# Altium schematic sheet DSP.SchDoc
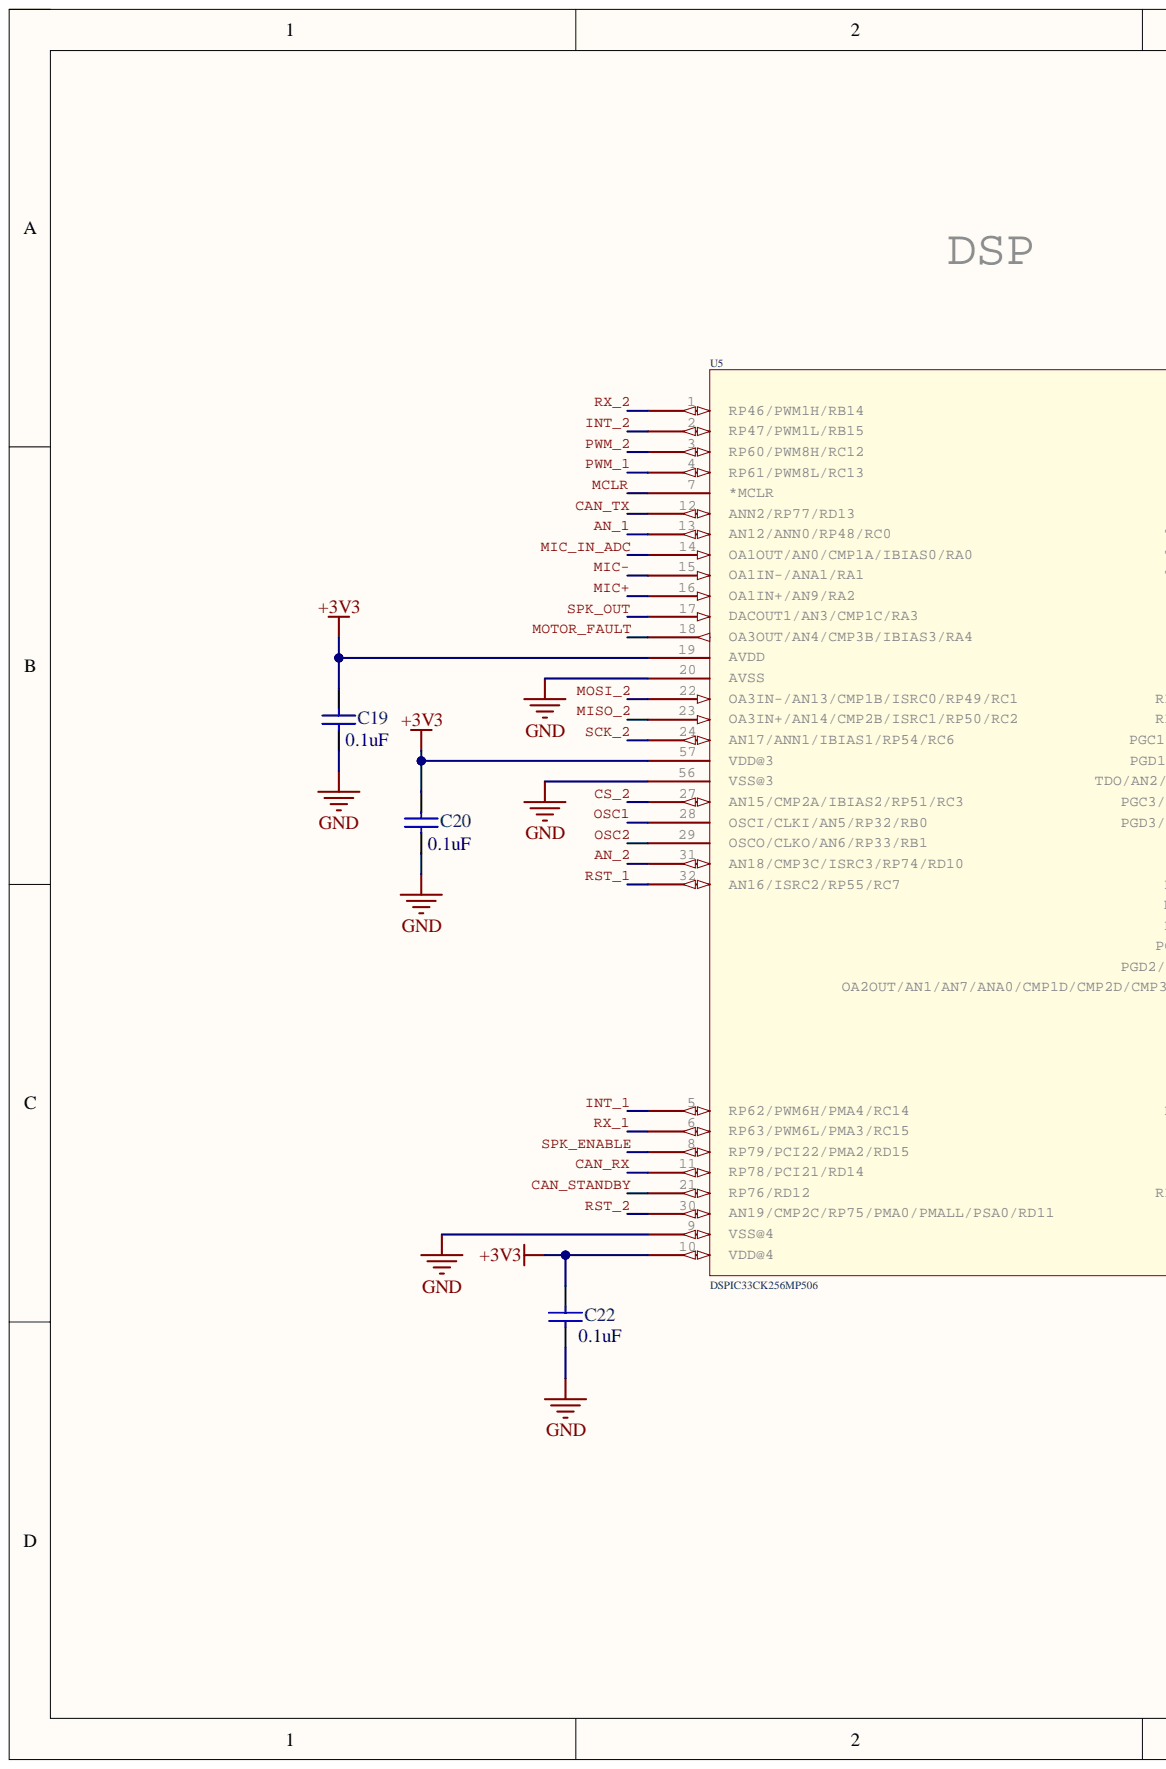
[diagram p0: DSP.SchDoc, left]
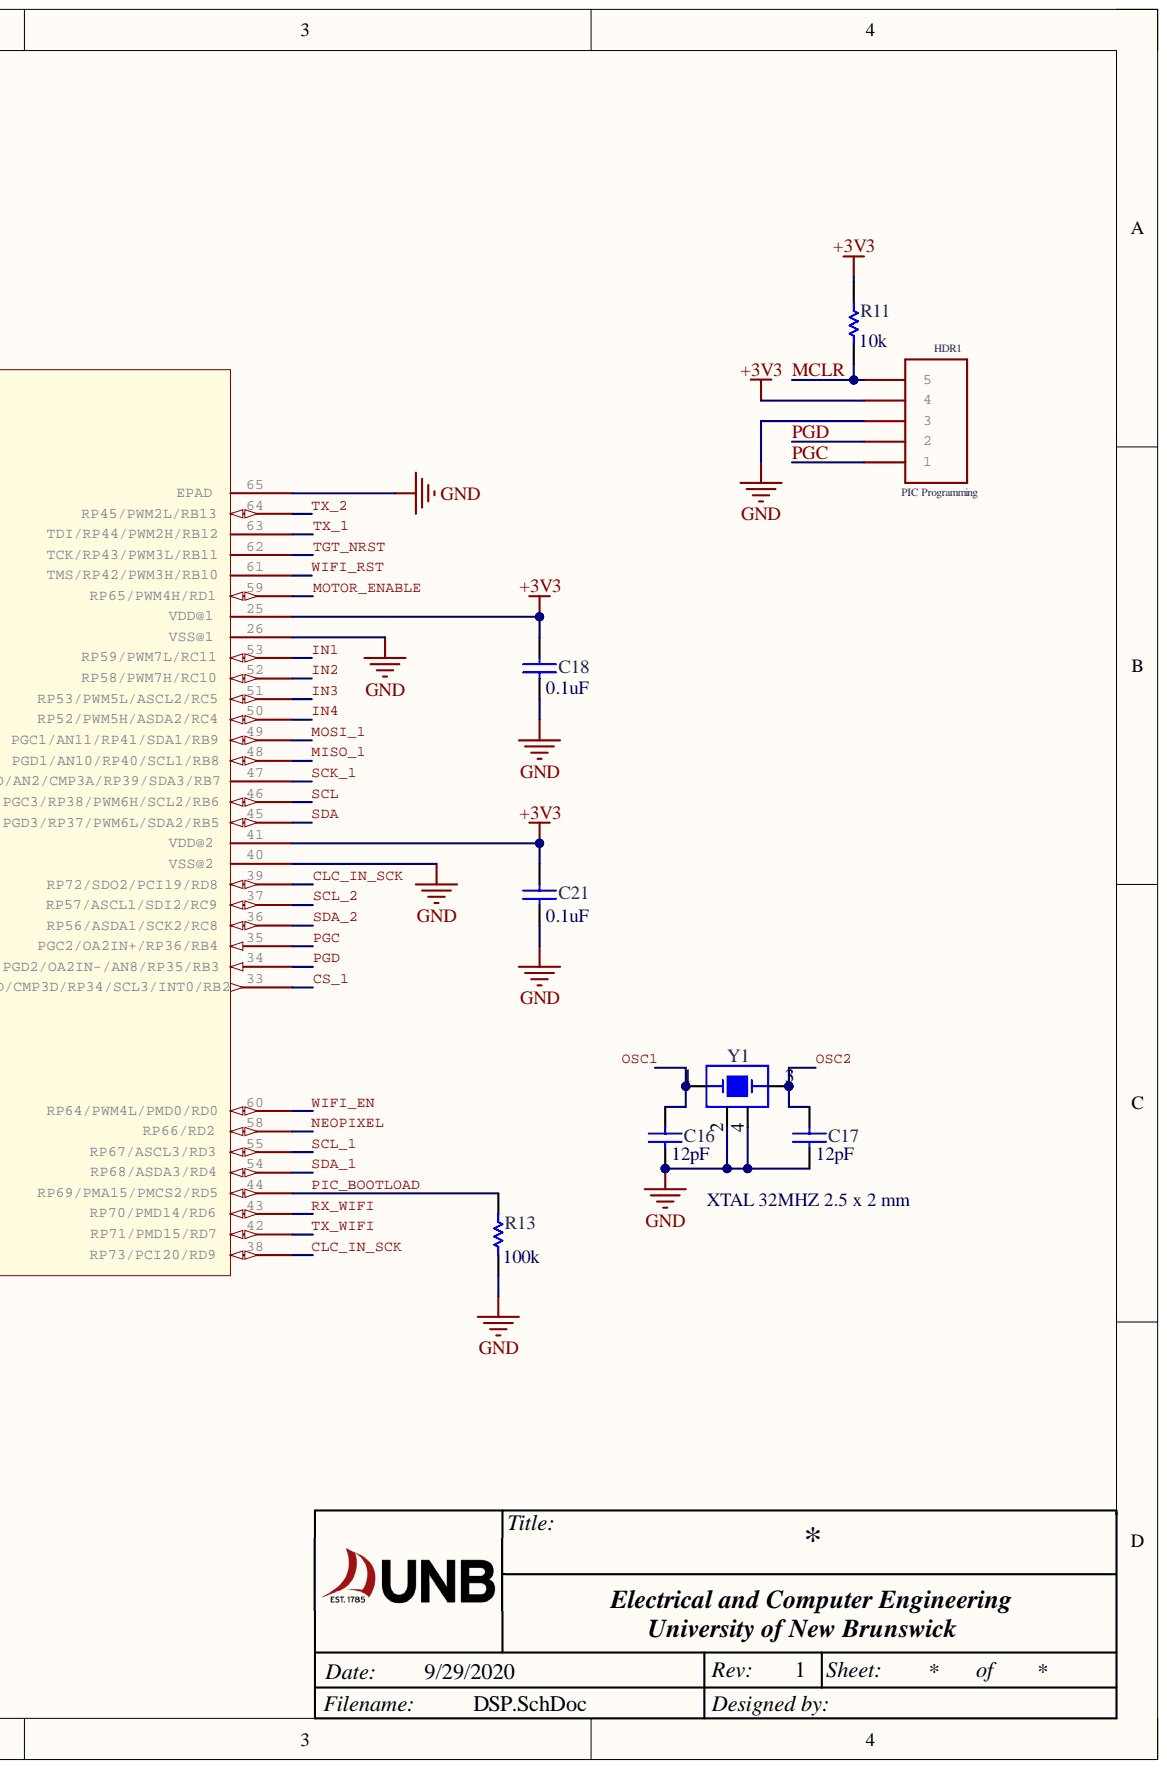
[diagram p1: DSP.SchDoc, right]

## Parts
C16  12pF  [0603 Cap]
C17  12pF  [0603 Cap]
C18  0.1uF  [0603 Cap]
C19  0.1uF  [0603 Cap]
C20  0.1uF  [0603 Cap]
C21  0.1uF  [0603 Cap]
C22  0.1uF  [0603 Cap]
HDR1  PIC Programming  [HDR1X5]  (value not drawn)
R11  10k  [0603 Resistor]
R13  100k  [0603 Resistor]
U5  DSPIC33CK256MP506  [QFN50P900X900X100-65N]
Y1  XTAL 32MHZ 2.5 x 2 mm  [BT-XTAL_2520]

## Nets
+3V3: C18.2 C19.2 C20.2 C21.2 C22.2 HDR1.4 R11.2 U5.10(VDD@4) U5.19(AVDD) U5.25(VDD@1) U5.41(VDD@2) U5.57(VDD@3)
GND: C16.1 C17.1 C18.1 C19.1 C20.1 C21.1 C22.1 HDR1.3 R13.1 U5.9(VSS@4) U5.20(AVSS) U5.26(VSS@1) U5.40(VSS@2) U5.56(VSS@3) U5.65(EPAD) Y1.2 Y1.4
AN_1: U5.13(AN12/ANN0/RP48/RC0)
AN_2: U5.31(AN18/CMP3C/ISRC3/RP74/RD10)
CAN_RX: U5.11(RP78/PCI21/RD14)
CAN_STANDBY: U5.21(RP76/RD12)
CAN_TX: U5.12(ANN2/RP77/RD13)
CLC_IN_SCK: U5.38(RP73/PCI20/RD9) U5.39(RP72/SDO2/PCI19/RD8)
CS_1: U5.33(OA2OUT/AN1/AN7/ANA0/CMP1D/CMP2D/CMP3D/RP34/SCL3/INT0/RB2)
CS_2: U5.27(AN15/CMP2A/IBIAS2/RP51/RC3)
IN1: U5.53(RP59/PWM7L/RC11)
IN2: U5.52(RP58/PWM7H/RC10)
IN3: U5.51(RP53/PWM5L/ASCL2/RC5)
IN4: U5.50(RP52/PWM5H/ASDA2/RC4)
INT_1: U5.5(RP62/PWM6H/PMA4/RC14)
INT_2: U5.2(RP47/PWM1L/RB15)
MCLR: HDR1.5 R11.1 U5.7(*MCLR)
MIC+: U5.16(OA1IN+/AN9/RA2)
MIC-: U5.15(OA1IN-/ANA1/RA1)
MIC_IN_ADC: U5.14(OA1OUT/AN0/CMP1A/IBIAS0/RA0)
MISO_1: U5.48(PGD1/AN10/RP40/SCL1/RB8)
MISO_2: U5.23(OA3IN+/AN14/CMP2B/ISRC1/RP50/RC2)
MOSI_1: U5.49(PGC1/AN11/RP41/SDA1/RB9)
MOSI_2: U5.22(OA3IN-/AN13/CMP1B/ISRC0/RP49/RC1)
MOTOR_ENABLE: U5.59(RP65/PWM4H/RD1)
MOTOR_FAULT: U5.18(OA3OUT/AN4/CMP3B/IBIAS3/RA4)
NEOPIXEL: U5.58(RP66/RD2)
OSC1: C16.2 U5.28(OSCI/CLKI/AN5/RP32/RB0) Y1.1
OSC2: C17.2 U5.29(OSCO/CLKO/AN6/RP33/RB1) Y1.3
PGC: HDR1.1 U5.35(PGC2/OA2IN+/RP36/RB4)
PGD: HDR1.2 U5.34(PGD2/OA2IN-/AN8/RP35/RB3)
PIC_BOOTLOAD: R13.2 U5.44(RP69/PMA15/PMCS2/RD5)
PWM_1: U5.4(RP61/PWM8L/RC13)
PWM_2: U5.3(RP60/PWM8H/RC12)
RST_1: U5.32(AN16/ISRC2/RP55/RC7)
RST_2: U5.30(AN19/CMP2C/RP75/PMA0/PMALL/PSA0/RD11)
RX_1: U5.6(RP63/PWM6L/PMA3/RC15)
RX_2: U5.1(RP46/PWM1H/RB14)
RX_WIFI: U5.43(RP70/PMD14/RD6)
SCK_1: U5.47(TDO/AN2/CMP3A/RP39/SDA3/RB7)
SCK_2: U5.24(AN17/ANN1/IBIAS1/RP54/RC6)
SCL: U5.46(PGC3/RP38/PWM6H/SCL2/RB6)
SCL_1: U5.55(RP67/ASCL3/RD3)
SCL_2: U5.37(RP57/ASCL1/SDI2/RC9)
SDA: U5.45(PGD3/RP37/PWM6L/SDA2/RB5)
SDA_1: U5.54(RP68/ASDA3/RD4)
SDA_2: U5.36(RP56/ASDA1/SCK2/RC8)
SPK_ENABLE: U5.8(RP79/PCI22/PMA2/RD15)
SPK_OUT: U5.17(DACOUT1/AN3/CMP1C/RA3)
TGT_NRST: U5.62(TCK/RP43/PWM3L/RB11)
TX_1: U5.63(TDI/RP44/PWM2H/RB12)
TX_2: U5.64(RP45/PWM2L/RB13)
TX_WIFI: U5.42(RP71/PMD15/RD7)
WIFI_EN: U5.60(RP64/PWM4L/PMD0/RD0)
WIFI_RST: U5.61(TMS/RP42/PWM3H/RB10)

## Library data of the parts
C16 C17 = Cap Semi: Cap Ceramic 12pF 50V C0G 5% SMD 0603 125C T/R; Manufacturer: Yageo; Manufacturer Part Number: CC0603JRNPO9BN120; Insulation Resistance: 100GR; Capacitance: 12pF; Case Code (Imperial): 0603; Case Code (Metric): 1608; Case/Package: 0603; Category: Ceramic Capacitors; Component Kind: Standard; ComponentLink1Description: http://www.avx.com/docs/Catalogs/cx7r.pdf; ComponentLink1URL: http://www.avx.com/docs/Catalogs/cx7r.pdf; ComponentLink2Description: https://datasheet.ciiva.com/11587/upy-gp-np0-16v-to-50v-8-11587083.pdf?src-supplier=Avnet; ComponentLink2URL: https://datasheet.ciiva.com/11587/upy-gp-np0-16v-to-50v-8-11587083.pdf?src-supplier=Avnet; ComponentLink3Description: https://datasheet.ciiva.com/17434/upy-gp-np0-16v-to-50v-8-17434331.pdf?src-supplier=Avnet+Europe; ComponentLink3URL: https://datasheet.ciiva.com/17434/upy-gp-np0-16v-to-50v-8-17434331.pdf?src-supplier=Avnet+Europe; ComponentLink4Description: https://datasheet.ciiva.com/161/mlccorderingcode-161486.pdf?src-supplier=Digi-Key; ComponentLink4URL: https://datasheet.ciiva.com/161/mlccorderingcode-161486.pdf?src-supplier=Digi-Key; ComponentLink5Description: https://datasheet.ciiva.com/28450/1443161-28450222.pdf?src-supplier=Element14; ComponentLink5URL: https://datasheet.ciiva.com/28450/1443161-28450222.pdf?src-supplier=Element14; ComponentLink6Description: https://datasheet.ciiva.com/161/mlccorderingcode-161487.pdf?src-supplier=Digi-Key; ComponentLink6URL: https://datasheet.ciiva.com/161/mlccorderingcode-161487.pdf?src-supplier=Digi-Key; ComponentLink7Description: https://datasheet.ciiva.com/161/mlccorderingcode-161488.pdf?src-supplier=Digi-Key; ComponentLink7URL: https://datasheet.ciiva.com/161/mlccorderingcode-161488.pdf?src-supplier=Digi-Key; ComponentLink8Description: https://datasheet.ciiva.com/5425/upy-gp-np0-16v-to-50v-8-5425431.pdf?src-supplier=Mouser; ComponentLink8URL: https://datasheet.ciiva.com/5425/upy-gp-np0-16v-to-50v-8-5425431.pdf?src-supplier=Mouser; ComponentLink9Description: https://datasheet.ciiva.com/144/mlccorderingcode-144448.pdf?src-supplier=Digi-Key; ComponentLink9URL: https://datasheet.ciiva.com/144/mlccorderingcode-144448.pdf?src-supplier=Digi-Key; ComponentLink10Description: https://datasheet.ciiva.com/144/mlccorderingcode-144449.pdf?src-supplier=Digi-Key; ComponentLink10URL: https://datasheet.ciiva.com/144/mlccorderingcode-144449.pdf?src-supplier=Digi-Key; ComponentLink11Description: https://datasheet.ciiva.com/144/mlccorderingcode-144450.pdf?src-supplier=Digi-Key; ComponentLink11URL: https://datasheet.ciiva.com/144/mlccorderingcode-144450.pdf?src-supplier=Digi-Key; ComponentLink12Description: https://datasheet.ciiva.com/8033/1734582-8033717.pdf?src-supplier=Element14; ComponentLink12URL: https://datasheet.ciiva.com/8033/1734582-8033717.pdf?src-supplier=Element14; ComponentLink13Description: https://datasheet.ciiva.com/5425/upy-gp-np0-16v-to-50v-8-5425478.pdf?src-supplier=Mouser; ComponentLink13URL: https://datasheet.ciiva.com/5425/upy-gp-np0-16v-to-50v-8-5425478.pdf?src-supplier=Mouser; ComponentLink14Description: https://datasheet.ciiva.com/8033/1734582-8033718.pdf?src-supplier=Element14; ComponentLink14URL: https://datasheet.ciiva.com/8033/1734582-8033718.pdf?src-supplier=Element14; ComponentLink15Description: https://datasheet.ciiva.com/11464/upy-gp-np0-16v-to-50v-8-11464726.pdf?src-supplier=Avnet; ComponentLink15URL: https://datasheet.ciiva.com/11464/upy-gp-np0-16v-to-50v-8-11464726.pdf?src-supplier=Avnet; ComponentLink16Description: https://datasheet.ciiva.com/28450/1443161-28450470.pdf?src-supplier=Element14; ComponentLink16URL: https://datasheet.ciiva.com/28450/1443161-28450470.pdf?src-supplier=Element14; ComponentLink17Description: https://datasheet.ciiva.com/16625/upy-gp-np0-16v-to-50v-8-16625516.pdf?src-supplier=Avnet+Europe; ComponentLink17URL: https://datasheet.ciiva.com/16625/upy-gp-np0-16v-to-50v-8-16625516.pdf?src-supplier=Avnet+Europe; Composition: Ceramic; Depth: 0.8mm; Dielectric: C0G; Dielectric Material: Ceramic; Height: 0.8mm; Lead Free: Lead Free; Length: 1.6mm; Library Name: CAN_Interface_Library.SCHLIB; Max Operating Temperature: 125°C; Min Operating Temperature: -55°C; Mount: Surface Mount; Number of Pins: 2; Package Quantity: 1; Packaging: Tape and Reel; Radiation Hardening: No; REACH SVHC: No SVHC; RoHS: Yes; Signal Integrity: Cap Semi; Simulation: CAP; Stock 1: 251860; Supplier 1: Digi-Key; Supplier Part Number 1: 311-1059-1-ND; Termination: SMD/SMT; Thickness: 0.035inch; Tolerance: 5%; Value: 12pF; Voltage Rating: 50V; Voltage Rating (DC): 50V; Width: 0.031inch
C18-C22 = Cap Semi: Cap Ceramic 0.1uF 50VDC X7R 10% SMD 0603 Paper T/R; Manufacturer: Samsung; Manufacturer Part Number: CL10B104KB8NNNC; Capacitance: 100nF; Voltage: 50V; Case Code (Imperial): 0603; Case Code (Metric): 1608; Case/Package: 0603; Category: Ceramic Capacitors; Component Kind: Standard; ComponentLink1Description: http://www.avx.com/docs/Catalogs/cx7r.pdf; ComponentLink1URL: http://www.avx.com/docs/Catalogs/cx7r.pdf; ComponentLink2Description: https://datasheet.ciiva.com/25803/cl10b104kb8nnnc-25803393.pdf?src-supplier=Digi-Key; ComponentLink2URL: https://datasheet.ciiva.com/25803/cl10b104kb8nnnc-25803393.pdf?src-supplier=Digi-Key; ComponentLink3Description: https://datasheet.ciiva.com/26838/getdatasheetpartid-89097-26838411.pdf?src-supplier=Verical; ComponentLink3URL: https://datasheet.ciiva.com/26838/getdatasheetpartid-89097-26838411.pdf?src-supplier=Verical; ComponentLink4Description: https://datasheet.ciiva.com/29198/456651-da-01-en-vs-kondensator-0605-29198839.pdf?src-supplier=Conrad; ComponentLink4URL: https://datasheet.ciiva.com/29198/456651-da-01-en-vs-kondensator-0605-29198839.pdf?src-supplier=Conrad; Contact Plating: Tin; Depth: 0.8mm; Dielectric: X7R; Height: 0.8mm; Lead Free: Lead Free; Length: 1.6mm; Library Name: CAN_Interface_Library.SCHLIB; Material: Ceramic; Max Operating Temperature: 125°C; Min Operating Temperature: -55°C; Mount: Surface Mount; Package Quantity: 1; Packaging: Tape and Reel; Radiation Hardening: No; RoHS: Yes; Signal Integrity: Cap Semi; Simulation: CAP; Stock 1: 17879275; Supplier 1: Digi-Key; Supplier Part Number 1: 1276-1000-6-ND; Thickness: 0.035inch; Tolerance: 10%; Value: 0.1uF; Voltage Rating: 50V; Voltage Rating (DC): 50V; Width: 0.031inch
HDR1 = HEADER1X51X5: CONN HDR 5POS 0.1 TIN PCB; Manufacturer: Sullins; Manufacturer Part Number: PPTC051LFBN-RC; Connector Type: Header,Socket; Number of Positions: 5; Pitch: 0.1inch; Category: Connectors; Color: Black; Component Kind: Standard; ComponentLink1Description: https://media.digikey.com/pdf/Data%20Sheets/Sullins%20PDFs/Female_Headers.100_DS.pdf?src-supplier=Digi-Key; ComponentLink1URL: https://media.digikey.com/pdf/Data%20Sheets/Sullins%20PDFs/Female_Headers.100_DS.pdf?src-supplier=Digi-Key; ComponentLink2Description: http://www.sullinscorp.com/catalogs/78_Page80-81_HEADER_.100_2.54mm_FEMALE.pdf?src-supplier=Future+Electronics; ComponentLink2URL: http://www.sullinscorp.com/catalogs/78_Page80-81_HEADER_.100_2.54mm_FEMALE.pdf?src-supplier=Future+Electronics; Contact Material: Bronze; Contact Plating: Tin; Height: 8.5mm; Housing Material: Plastic; Lead Free: Lead Free; Length: 13.2mm; Mount: Through Hole; Number of Rows: 1; Package Quantity: 1000; Packaging: Bulk; RoHS: Yes; Stock 1: 10988; Supplier 1: Digi-Key; Supplier Part Number 1: S6103-ND; Termination: Solder; Width: 2.5mm; Library Name: BrilliantLabsEagleLibrary.IntLib
R11 = Res Semi: Res Thick Film 0603 10K Ohm 1% 0.1W(1/10W) ±100ppm/C Molded SMD Automotive T/R; Manufacturer: Stackpole Electronics; Manufacturer Part Number: RMCF0603FT10K0; Max Power Dissipation: 100mW; Resistance: 10kR; Temperature Coefficient: 100ppm/°C; Case Code (Imperial): 0603; Case Code (Metric): 1608; Case/Package: 0603; Category: Chip SMD Resistors; Component Kind: Standard; ComponentLink1Description: http://www.rohm.com/web/global/datasheet/ESR01MZPF/esr-e; ComponentLink1URL: http://www.rohm.com/web/global/datasheet/ESR01MZPF/esr-e; ComponentLink2Description: http://components.arrow.com/part/dataview/43747082S6756917N1174?src-supplier=Arrow+Electronics; ComponentLink2URL: http://components.arrow.com/part/dataview/43747082S6756917N1174?src-supplier=Arrow+Electronics; ComponentLink3Description: https://www.seielect.com/catalog/sei-rmcf_rmcp.pdf?src-supplier=Digi-Key; ComponentLink3URL: https://www.seielect.com/catalog/sei-rmcf_rmcp.pdf?src-supplier=Digi-Key; ComponentLink4Description: http://www.seielect.com/catalog/sei-rmc.pdf?src-supplier=Future+Electronics; ComponentLink4URL: http://www.seielect.com/catalog/sei-rmc.pdf?src-supplier=Future+Electronics; ComponentLink5Description: https://www.seielect.com/catalog/SEI-RMCF_RMCP.pdf?src-supplier=Avnet; ComponentLink5URL: https://www.seielect.com/catalog/SEI-RMCF_RMCP.pdf?src-supplier=Avnet; ComponentLink6Description: https://www.verical.com/server-webapp/html/getDatasheet?partID=360913&src-supplier=Verical; ComponentLink6URL: https://www.verical.com/server-webapp/html/getDatasheet?partID=360913&src-supplier=Verical; Composition: Thick Film; Contact Plating: Tin,Matte; Depth: 0.8mm; Features: Automotive AEC-Q200; Height: 0.022inch; Lead Free: Lead Free; Length: 1.55mm; Max Operating Temperature: 155°C; Military Standard: Not; Min Operating Temperature: -55°C; Mount: Surface Mount; Number of Terminations: 2; Packaging: Tape and Reel; Power Rating: 100mW; Radiation Hardening: No; RoHS: Yes; Signal Integrity: Res Semi; Simulation: RES; Stock 1: 1608506; Supplier 1: Digi-Key; Supplier Part Number 1: RMCF0603FT10K0CT-ND; Tolerance: 1%; Value: 10k; Width: 0.031inch; Library Name: BrillianBit.SCHLIB
R13 = Res Semi: RES 100K OHM 1% 1/10W 0603; Manufacturer: Stackpole Electronics; Manufacturer Part Number: RMCF0603FT100K; Max Power Dissipation: 100mW; Resistance: 100kR; Temperature Coefficient: 100ppm/°C; Working Voltage: 75V; Case Code (Imperial): 0603; Case Code (Metric): 1608; Case/Package: 0603; Category: Chip SMD Resistors; Component Kind: Standard; ComponentLink1Description: http://www.rohm.com/web/global/datasheet/ESR01MZPF/esr-e; ComponentLink1URL: http://www.rohm.com/web/global/datasheet/ESR01MZPF/esr-e; ComponentLink2Description: https://datasheet.ciiva.com/15193/getdatasheetpartid-362597-15193437.pdf?src-supplier=Verical; ComponentLink2URL: https://datasheet.ciiva.com/15193/getdatasheetpartid-362597-15193437.pdf?src-supplier=Verical; Composition: Thick Film; Contact Plating: Tin,Matte; Depth: 0.8mm; Features: Automotive AEC-Q200; Height: 0.022inch; Lead Free: Lead Free; Length: 1.55mm; Max Operating Temperature: 155°C; Military Standard: Not; Min Operating Temperature: -55°C; Mount: Surface Mount; Number of Terminations: 2; Packaging: Tape and Reel; Power Rating: 100mW; Radiation Hardening: No; RoHS: Yes; Signal Integrity: Res Semi; Simulation: RES; Stock 1: 2666095; Supplier 1: Digi-Key; Supplier Part Number 1: RMCF0603FT100KDKR-ND; Tolerance: 1%; Value: 100k; Width: 0.031inch; Library Name: BrillianBit.SCHLIB
U5 = DSPIC33CK256MP506: DSC, 16BIT, 256KB, 3.6V, QFN-64; Manufacturer: Microchip; Manufacturer Part Number: DSPIC33CK256MP506-I/MR; Category: Microcontrollers; Component Kind: Standard; ComponentLink1Description: http://www.farnell.com/datasheets/2634607.pdf?src-supplier=Farnell; ComponentLink1URL: http://www.farnell.com/datasheets/2634607.pdf?src-supplier=Farnell; ComponentLink2Description: http://www.microchip.com/mymicrochip/filehandler.aspx?ddocname=en606227&src-supplier=Digi-Key; ComponentLink2URL: http://www.microchip.com/mymicrochip/filehandler.aspx?ddocname=en606227&src-supplier=Digi-Key; RoHS: No; Stock 1: 331; Supplier 1: Digi-Key; Supplier Part Number 1: DSPIC33CK256MP506-I/MR-ND; Library Name: BrilliantLabsEagleLibrary.SCHLIB
Y1 = XTAL_16MHZ_3.2X2.5MM: Manufacturer: ECS International; Manufacturer Part Number: ECS-320-10-36B-CKM-TR; Component Kind: Standard; Description: XTAL 32MHZ 2.5 x 2 mm; RoHS: No; Supplier 1: Digi-Key; Supplier Part Number 1: 50-ECS-320-10-36B-CKM-TR-ND; Library Name: Arbury_SCH.SchLib
